# Altium schematic sheet 05-SD_Card.SchDoc
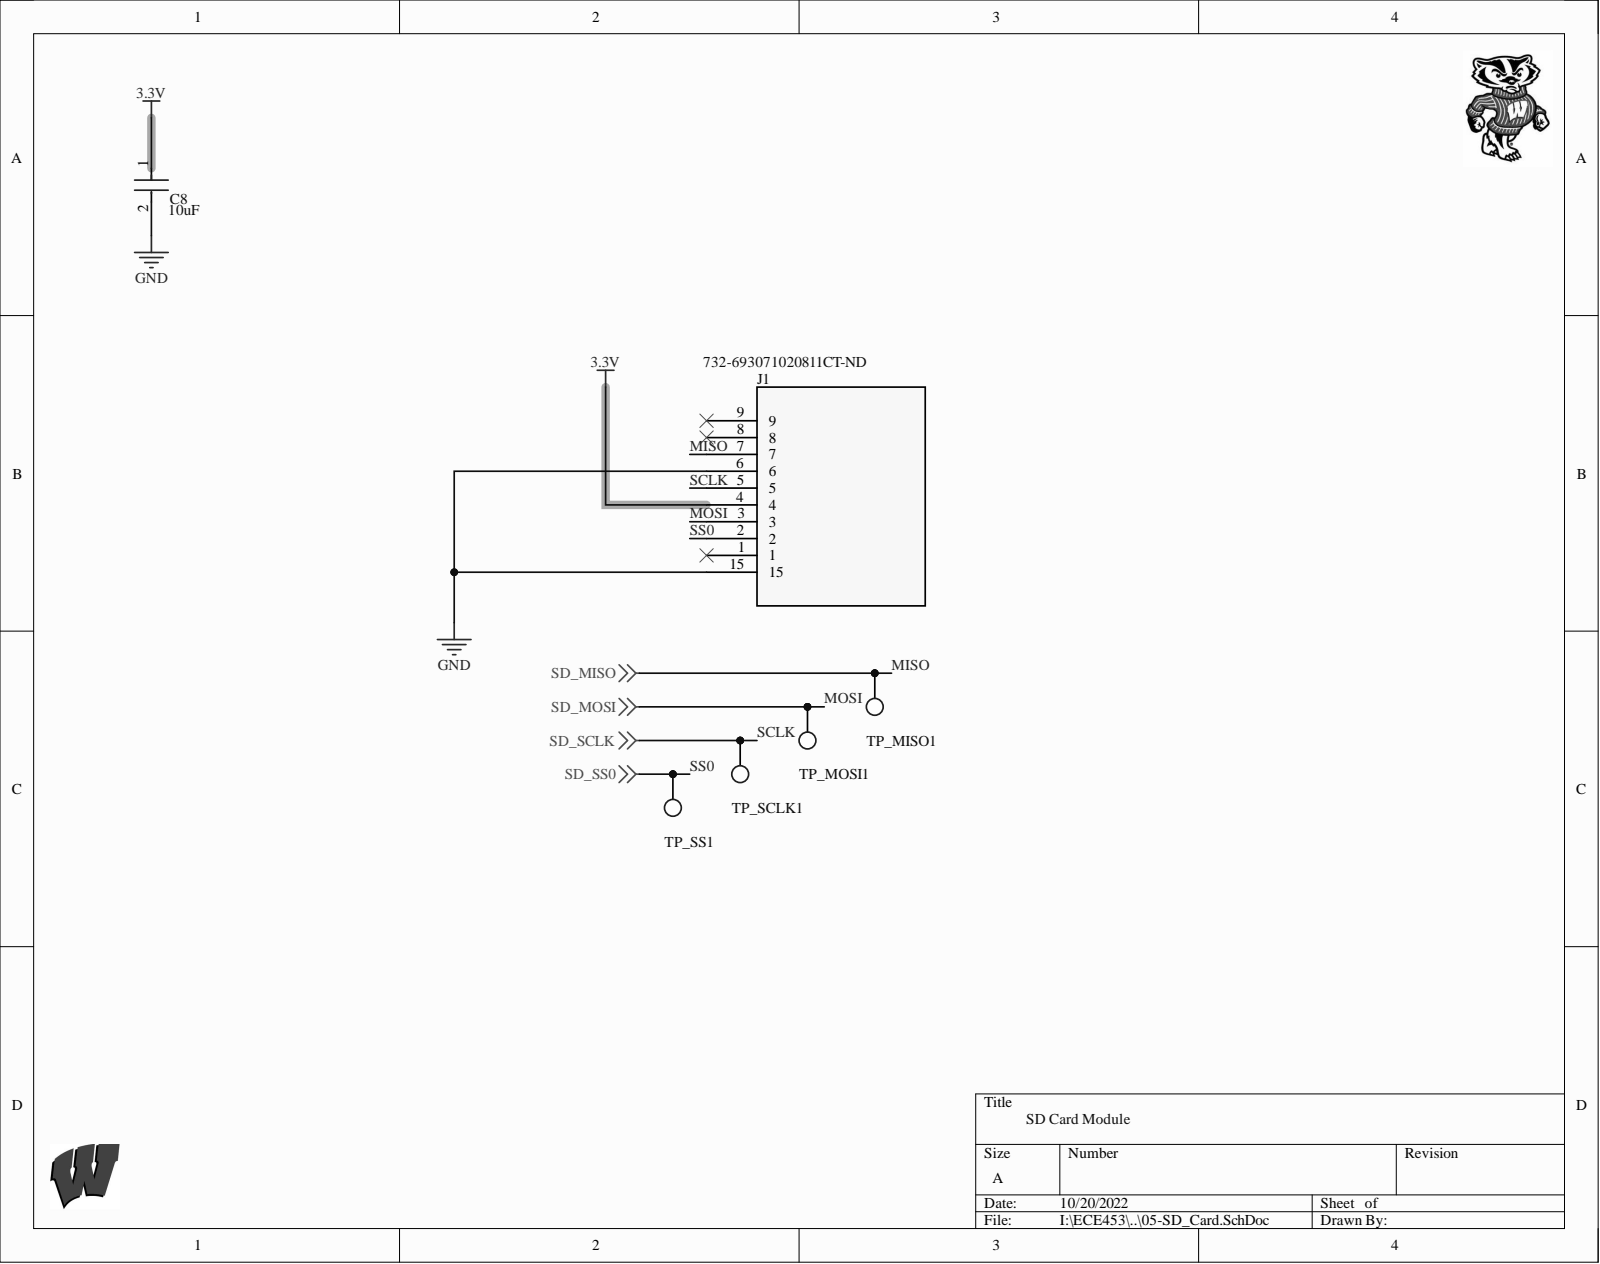
[diagram p0: 05-SD_Card.SchDoc]

## Parts
C8  Capacitance: 10uF  [FP-CL21-IPC_B]  (hidden comment: CL21A106KQCLNNC)
J1  Digi-Key_Part_Number_2: 732-693071020811CT-ND  [CONN9_020811_WRE]
TP_MISO1  TP_1206  [TP-SMC-1206]  (value not drawn)
TP_MOSI1  TP_1206  [TP-SMC-1206]  (value not drawn)
TP_SCLK1  TP_1206  [TP-SMC-1206]  (value not drawn)
TP_SS1  TP_1206  [TP-SMC-1206]  (value not drawn)

## Nets
3.3V: C8.1 J1.4
GND: C8.2 J1.6 J1.15
MISO: J1.7 TP_MISO1.1
MOSI: J1.3 TP_MOSI1.1
SCLK: J1.5 TP_SCLK1.1
SS0: J1.2 TP_SS1.1

Not connected: J1 1 8 9

## Library data of the parts
C8 = CMP-13271-003047-1: CL21 Series 0805 10uF 6.3V ±10% Tolerance X5R Multilayer Ceramic Chip Capacitor; Case Code (Imperial): 0805; Case Code (Metric): 2012; Case/Package: 0805; Component Kind: Standard; Depth: 1.25mm; Dielectric: X5R; Height: 0.85mm; Lead Free: Lead Free; Length: 2mm; Library Name: Altium Content Vault; Max Operating Temperature: 85°C; Min Operating Temperature: -55°C; Mount: Surface Mount; Package Quantity: 1; Packaging: Tape and Reel; Radiation Hardening: No; Thickness: 0.037inch; Tolerance: 10%; Voltage Rating: 6.3V; Width: 0.049inch
J1 = 693071020811: No Description Available; Mfr_Name: Wurth Electronics; Component Kind: Standard; Digi-Key_Part_Number_1: 732-693071020811TR-ND; Digi-Key_Part_Number_3: 732-693071020811DKR-ND; Library Name: team6.SCHLIB; Manufacturer_Part_Number: 693071020811; RefDes: RefDes; Supplier 1: Digi-Key; Supplier Part Number 1: 732-693071020811CT-ND; Type: DEV; Copyright: Copyright (C) 2022 Ultra Librarian. All rights reserved.
TP_MISO1 TP_MOSI1 TP_SCLK1 TP_SS1 = TP_1206: Test Point SMD 1206; Manufacturer: TE Connectivity (Tyco Electronics); Manufacturer Part Number: 1625854-1; Power Rating: N/R; Resistance Value: 0 Ohm; Case Size: 1206; Component Kind: Standard; ComponentLink1Description: https://datasheet.ciiva.com/6439/eng-ds-1773266-a-6439169.pdf; ComponentLink1URL: https://datasheet.ciiva.com/6439/eng-ds-1773266-a-6439169.pdf; ComponentLink2Description: https://datasheet.ciiva.com/30136/1773266-a-30136341.pdf; ComponentLink2URL: https://datasheet.ciiva.com/30136/1773266-a-30136341.pdf; ComponentLink3Description: https://datasheet.ciiva.com/6439/eng-ds-1773266-a-6439080.pdf; ComponentLink3URL: https://datasheet.ciiva.com/6439/eng-ds-1773266-a-6439080.pdf; ComponentLink4Description: https://datasheet.ciiva.com/6439/eng-ds-1773266-a-6439081.pdf; ComponentLink4URL: https://datasheet.ciiva.com/6439/eng-ds-1773266-a-6439081.pdf; ComponentLink5Description: https://datasheet.ciiva.com/6439/eng-ds-1773266-a-6439083.pdf; ComponentLink5URL: https://datasheet.ciiva.com/6439/eng-ds-1773266-a-6439083.pdf; Dimension: 3.2 x 1.6 x 1.25mm; Family: RC; Library Name: ECE453-F22-Power.SCHLIB; Mounting: Surface Mount; Operating Temperature: -55 to 125 C; Packaging: Tape and Reel; Part No: 1625854-1; Pricing 1: 1=0.28, 10=0.261, 25=0.224, 50=0.1902, 100=0.1828, 250=0.1641, 500=0.1567, 1000=0.1306 (USD); RoHS: Yes; Stock 1: 31937; Supplier: Digi-Key; Supplier Part Number: A106143CT-ND; Temperature Coefficient: N/R; Terminal Pitch: N/R; Termination Style: N/R; Tolerance: N/R
